# Altium schematic sheet lv_io_solenoid.SchDoc(lv_io_solenoid)
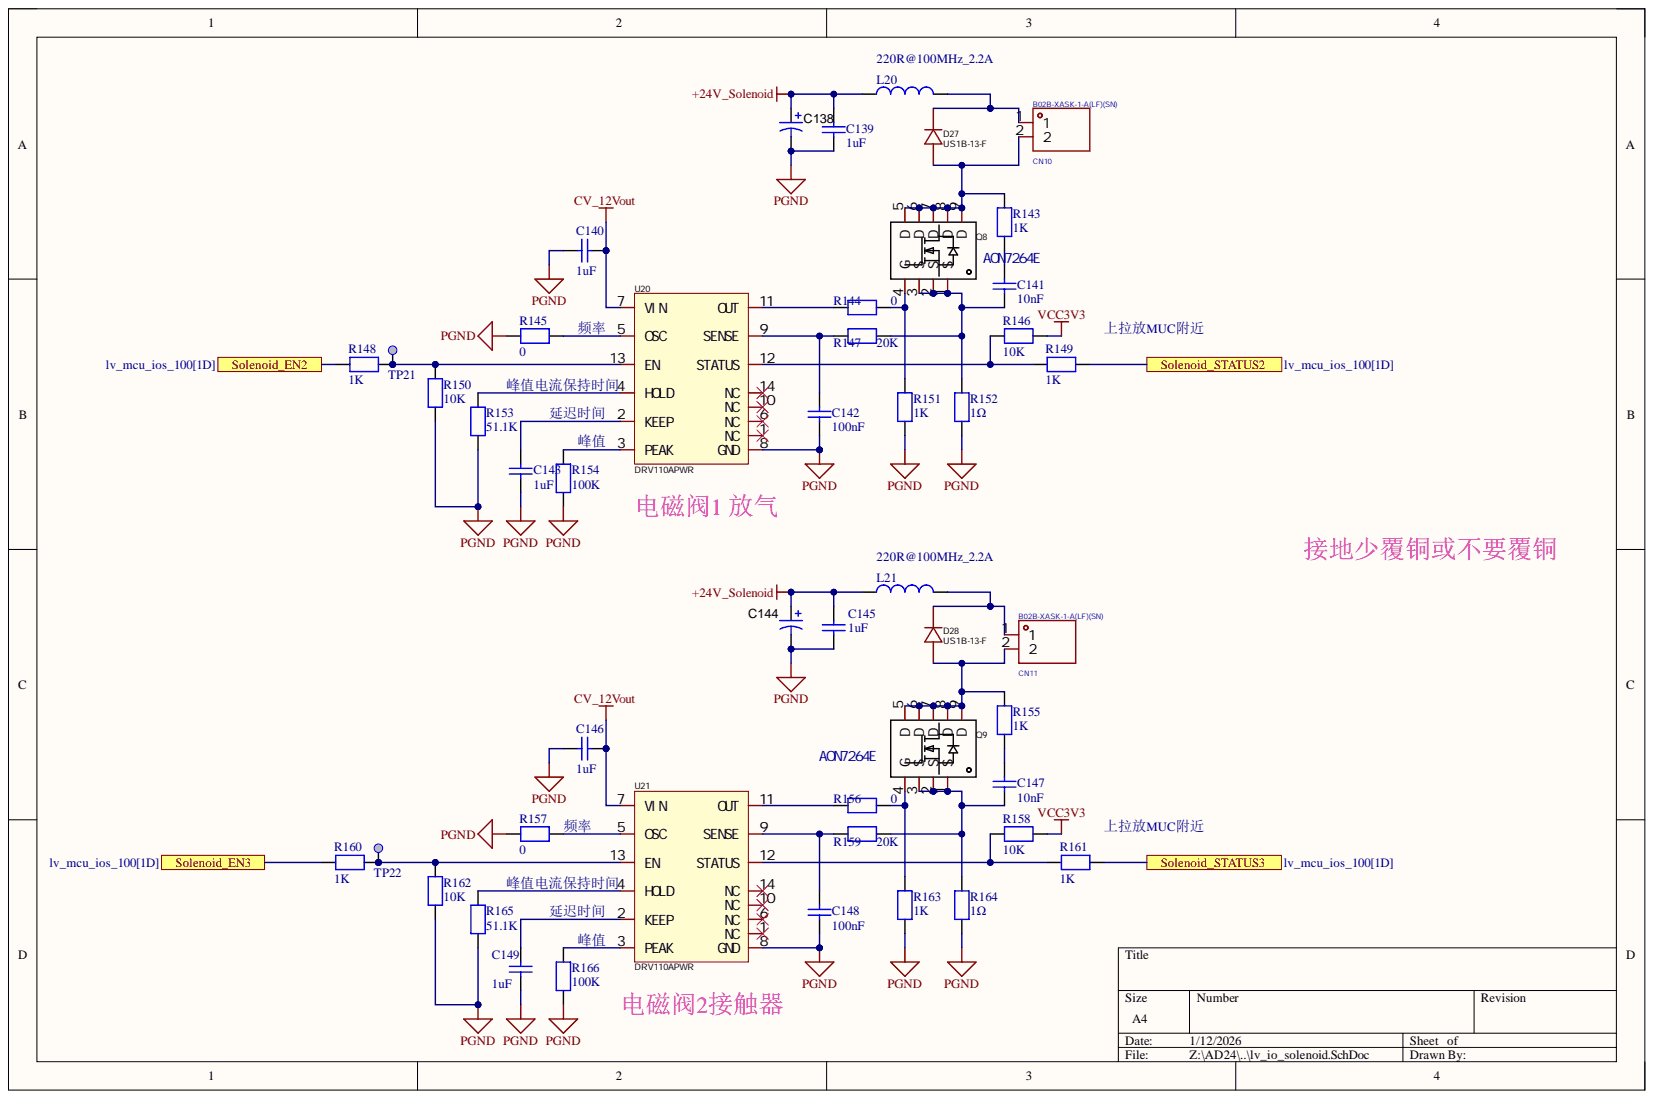
[diagram p0: lv_io_solenoid.SchDoc]

## Parts
C138  470uf/50V  [M_1000x2000]  (value not drawn)
C139  1uF  [C0603]
C140  1uF  [C0603]
C141  10nF  [C0603]
C142  value 100nF  [C0402]  (hidden comment: 100pF)
C143  1uF  [C0603]
C144  470uf/50V  [M_1000x2000]  (value not drawn)
C145  1uF  [C0603]
C146  1uF  [C0603]
C147  10nF  [C0603]
C148  value 100nF  [C0402]  (hidden comment: 100pF)
C149  1uF  [C0603]
CN10  B02B-XASK-1-A(LF)(SN)  [CONN-TH_B02B-XASK-1-A-LF-SN]
CN11  B02B-XASK-1-A(LF)(SN)  [CONN-TH_B02B-XASK-1-A-LF-SN]
D27  US1B-13-F  [SMA_L4.4-W2.8-LS5.4-RD]
D28  US1B-13-F  [SMA_L4.4-W2.8-LS5.4-RD]
L20  220R@100MHz_2.2A  [L0603]
L21  220R@100MHz_2.2A  [L0603]
Q8  AON7264E  [DFN-8_L3.0-W3.0-P0.65-BL_VBQFXXXX]
Q9  AON7264E  [DFN-8_L3.0-W3.0-P0.65-BL_VBQFXXXX]
R143  1K  [R0603]
R144  0  [R0603]
R145  0  [R0603]
R146  10K  [R0402]
R147  20K  [R0603]
R148  1K  [R0402]
R149  1K  value 10K  [R0402]
R150  10K  [R0603]
R151  1K  [R0603]
R152  1Ω  [C1210]
R153  51.1K  value 10K  [R0603]
R154  100K  [R0603]
R155  1K  [R0603]
R156  0  [R0603]
R157  0  [R0603]
R158  10K  [R0402]
R159  20K  [R0603]
R160  1K  [R0402]
R161  1K  [R0402]
R162  10K  [R0603]
R163  1K  [R0603]
R164  1Ω  [C1210]
R165  51.1K  value 10K  [R0603]
R166  100K  [R0603]
TP21  Test Point  [TP_SMD_1MM]  (value not drawn)  (no BOM)
TP22  Test Point  [TP_SMD_1MM]  (value not drawn)  (no BOM)
U20  DRV110APWR  [TSSOP-14_L5.0-W4.4-P0.65-LS6.4-BL]
U21  DRV110APWR  [TSSOP-14_L5.0-W4.4-P0.65-LS6.4-BL]

## Ports
Solenoid_EN2, Solenoid_EN3, Solenoid_STATUS2, Solenoid_STATUS3

## Nets
+24V_Solenoid: C138.1 C139.2 C144.1 C145.2 L20.2 L21.2
CV_12Vout: C140.1 C146.1 U20.7(VIN) U21.7(VIN)
PGND: C138.2 C139.1 C140.2 C142.1 C143.1 C144.2 C145.1 C146.2 C148.1 C149.1 R145.2 R150.2 R151.2 R152.2 R153.2 R154.2 R157.2 R162.2 R163.2 R164.2 R165.2 R166.2 U20.8(GND) U21.8(GND)
VCC3V3: R146.1 R158.1
Solenoid_EN2: R148.1 ; port Solenoid_EN2
Solenoid_EN3: R160.1 ; port Solenoid_EN3
Solenoid_STATUS2: R149.2 ; port Solenoid_STATUS2
Solenoid_STATUS3: R161.2 ; port Solenoid_STATUS3
NetC141_1: C141.1 Q8.1(S) Q8.2(S) Q8.3(S) R147.1 R152.1
NetC141_2: C141.2 R143.2
NetC142_2: C142.2 R147.2 U20.9(SENSE)
NetC143_2: C143.2 U20.2(KEEP)
NetC147_1: C147.1 Q9.1(S) Q9.2(S) Q9.3(S) R159.1 R164.1
NetC147_2: C147.2 R155.2
NetC148_2: C148.2 R159.2 U21.9(SENSE)
NetC149_2: C149.2 U21.2(KEEP)
NetCN10_1: CN10.1 D27.1 L20.1
NetCN10_2: CN10.2 D27.2 Q8.5(D) Q8.6(D) Q8.7(D) Q8.8(D) Q8.9(D) R143.1
NetCN11_1: CN11.1 D28.1 L21.1
NetCN11_2: CN11.2 D28.2 Q9.5(D) Q9.6(D) Q9.7(D) Q9.8(D) Q9.9(D) R155.1
NetQ8_4: Q8.4(G) R144.1 R151.1
NetQ9_4: Q9.4(G) R156.1 R163.1
NetR144_2: R144.2 U20.11(OUT)
NetR145_1: R145.1 U20.5(OSC)
NetR146_2: R146.2 R149.1 U20.12(STATUS)
NetR148_2: R148.2 R150.1 TP21.1 U20.13(EN)
NetR153_1: R153.1 U20.4(HOLD)
NetR154_1: R154.1 U20.3(PEAK)
NetR156_2: R156.2 U21.11(OUT)
NetR157_1: R157.1 U21.5(OSC)
NetR158_2: R158.2 R161.1 U21.12(STATUS)
NetR160_2: R160.2 R162.1 TP22.1 U21.13(EN)
NetR165_1: R165.1 U21.4(HOLD)
NetR166_1: R166.1 U21.3(PEAK)

Not connected: U20 1(NC) 6(NC) 10(NC) 14(NC); U21 1(NC) 6(NC) 10(NC) 14(NC)

## Library data of the parts
C138 C144 = ECA-1HM471: 470 µF, 50 V, +/- 20%; Manufacturer: HONOR(荣誉); Manufacturer_Part_Number: HG1H477M1016PC; Component Kind: Standard; ComponentLink1Description: Datasheet link; ComponentLink1URL: http://industrial.panasonic.com/www-data/pdf/ABA0000/ABA0000CE12.pdf; Library Name: DRV8701EVM.IntLib
C139 C140 C143 C145 C146 C149 = Capacitor_1: 50V, X5R, 10%; Manufacturer: muRata; Manufacturer_Part_Number: GRM188R61H105KAALD; Component Kind: Standard; ComponentLink1Description: Yageo_Capacitor_X5R-series.pdf; ComponentLink1URL: http://tunmcdsvn.tun.st.com/Component_Datasheet_Library/Trunk/Capacitors/Yageo_Multilayer_capacitor_X5R_series_100pF_to_100uF; Library Name: HiPin_Library_AD.IntLib
C141 C147 = Capacitor_1: 50V, X7R, 10%; Manufacturer: YAGEO; Manufacturer_Part_Number: CC0603KRX7R9BB103; Component Kind: Standard; ComponentLink1Description: Yageo_Capacitor_X5R-series.pdf; ComponentLink1URL: http://tunmcdsvn.tun.st.com/Component_Datasheet_Library/Trunk/Capacitors/Yageo_Multilayer_capacitor_X5R_series_100pF_to_100uF; Library Name: HiPin_Library_AD.IntLib; Package: C0603
C142 C148 = Capacitor: 50V, 10%, C0G; Internal_Part_Number: MCD-00003; Manufacturer: muRata; Manufacturer_Part_Number: GRM1555C1H101JA01D; Component Kind: Standard; ComponentLink1Description: Datasheet; ComponentLink1URL: http://tunmcdsvn.tun.st.com/Component_Datasheet_Library/Trunk/Capacitors/Yageo_Capacitor_NP0_16V-to-50V; Library Name: HiPin_Library_AD.IntLib; Package: C0402
CN10 CN11 = B02B-XASK-1-A(LF)(SN): XA插座，间距: 2.5mm，直插，H8.8mm; Manufacturer: JST; Add into BOM: yes; Current Rating (Max): 3A; Flame Retardant Rating: UL94V-0; Number of PINs Per Row: 2; Pins Structure: 1x2P; Pitch: 2.5mm; Plastic Material: 玻纤增强PA66; Rated Voltage (Max): 250V; Reference Series: XA; Supplementary Features: 带柱；打K脚; X-Length of Bottom Edge on Board (Spacing Line): 7.5mm; Y-Width of Bottom Edge on Board: 6.4mm; Z-Height of the Board: 8.8mm; Colour: 白色; Component Kind: Standard; Contact Material: 铜合金; Contact Plating: 锡; Convert to PCB: yes; Datasheet: https://atta.szlcsc.com/upload/public/pdf/source/20180829/C265077_AACBE43BFB9344D794AFC81CB60287FF.pdf; Device: B02B-XASK-1-A(LF)(SN); JLCPCB Part Class: Extended Part; LCSC Part Name: 1x2P 间距:2.5mm 直插 系列:XA; Library Name: HiPin_Library_AD.IntLib; Manufacturer Part: B02B-XASK-1-A(LF)(SN); Mounting Style: 直插; Number of Pins: 2P; Number of Rows: 1; Operating Temperature Range: -25℃~+85℃; PCB3D: CONN-TH_B02B-XASK-1-A-LF-SN; Row Spacing: -; Supplier: LCSC; Supplier Footprint: 插件,P=2.5mm; Supplier Part: C265077; Symbol: B02B-XASK-1-A(LF)(SN)
D27 D28 = US1B-13-F: Manufacturer: DIODES(美台); Add into BOM: yes; Average Rectified Current (Io): 1A; Forward Voltage (Vf@If): 1V@1A; Reverse Leakage Current: 5uA@100V; Reverse Recovery Time (trr): 50ns; Reverse Voltage (Vr): 100V; Component Kind: Standard; Convert to PCB: yes; Datasheet: https://atta.szlcsc.com/upload/public/pdf/source/20171129/C154439_15119539930141151354.pdf; Device: US1B-13-F; Diode Configuration: -; JLCPCB Part Class: Extended Part; LCSC Part Name: 电压:100V 电流:1A; Library Name: HiPin_Library_AD.IntLib; Manufacturer Part: US1B-13-F; Operating Temperature: -65℃~+150℃@(Tj); Supplier: LCSC; Supplier Footprint: SMA; Supplier Part: C154439; Symbol: US1B-13-F
L20 L21 = MCD-00047: SMD Chip ferrite bead, 220R@100MHz +/-25%,2.2A, 50mohm; Manufacturer: Sunlord; Manufacturer_Part_Number: UPZ1608U221-2R2TF; Database Table Name: MCD_PARTS$; Component Kind: Standard; ComponentLink1Description: Datasheet; ComponentLink1URL: http://tunmcdsvn.tun.st.com/Component_Datasheet_Library/Trunk/Inductors/TaiTech_Bead_FCM1608_series; Internal_Part_Number: MCD-00047; Library Name: MCD_PARTS_database.DbLib
Q8 Q9 = HYG045N03LA1C1: NMOS; Manufacturer: HUAYI(����΢); Add into BOM: yes; Continuous Drain Current (Id): 50A; Drain Source On Resistance (RDS(on)@Vgs,Id): 3.9m��@10V,20A; Drain Source Voltage (Vdss): 30V; Gate Threshold Voltage (Vgs(th)@Id): 1.6V@250uA; Input Capacitance (Ciss@Vds): 2.106nF@25V; Power Dissipation (Pd): 23W; Reverse Transfer Capacitance (Crss@Vds): 228pF@25V; Total Gate Charge (Qg@Vgs): 46.2nC@10V; Type: N����; Component Kind: Standard; Convert to PCB: yes; Datasheet: https://atta.szlcsc.com/upload/public/pdf/source/20211028/5F8459D5B1D3B8F85ED46FBAED5B3F3F.pdf; Device: HYG045N03LA1C1; JLCPCB Part Class: Extended Part; LCSC Part Name: N���� ��ѹ:30V ����:50A; Library Name: HiPin_Library_AD.IntLib; Manufacturer Part: HYG045N03LA1C1; Operating Temperature: -55��~+175��@(Tj); Supplier: LCSC; Supplier Footprint: DFN-8(3x3); Supplier Part: C2911691; Symbol: HYG045N03LA1C1
R143-R145 R147 R148 R151 R152 R154-R157 R159-R161 R163 R164 R166 = RES: 1%; Value: 10K; Component Kind: Standard; Library Name: HiPin_Library_AD.IntLib
R146 R149 R150 R153 R158 R162 R165 = RES: 1%; Component Kind: Standard; Library Name: HiPin_Library_AD.IntLib
  R146 R150 R158 R162: Value: 10K
TP21 TP22 = MCD-00074: Test Point 1mm; Part_Type: Mechanical; Database Table Name: MCD_PARTS$; ComponentLink1Description: Datasheet; ComponentLink1URL: http://na; ECCN_EU: n/a; ECCN_US: n/a; Internal_Part_Number: MCD-00074; Library Name: MCD_PARTS_database.DbLib; Manufacturer: n/a; Manufacturer_Part_Number: n/a; Provided_By: n/a; Status: Released
U20 U21 = DRV110APWR: Manufacturer: TI(德州仪器); Add into BOM: yes; Component Kind: Standard; Convert to PCB: yes; Datasheet: https://www.ti.com/cn/lit/gpn/drv110; Device: DRV110APWR; JLCPCB Part Class: Extended Part; LCSC Part Name: 330mA 15V电机驱动芯片; Library Name: HiPin_Library_AD.IntLib; Manufacturer Part: DRV110APWR; Supplier: LCSC; Supplier Footprint: TSSOP-14; Supplier Part: C2653796; Symbol: DRV110APWR
